# Altium schematic sheet interfaces.SchDoc(interfaces)
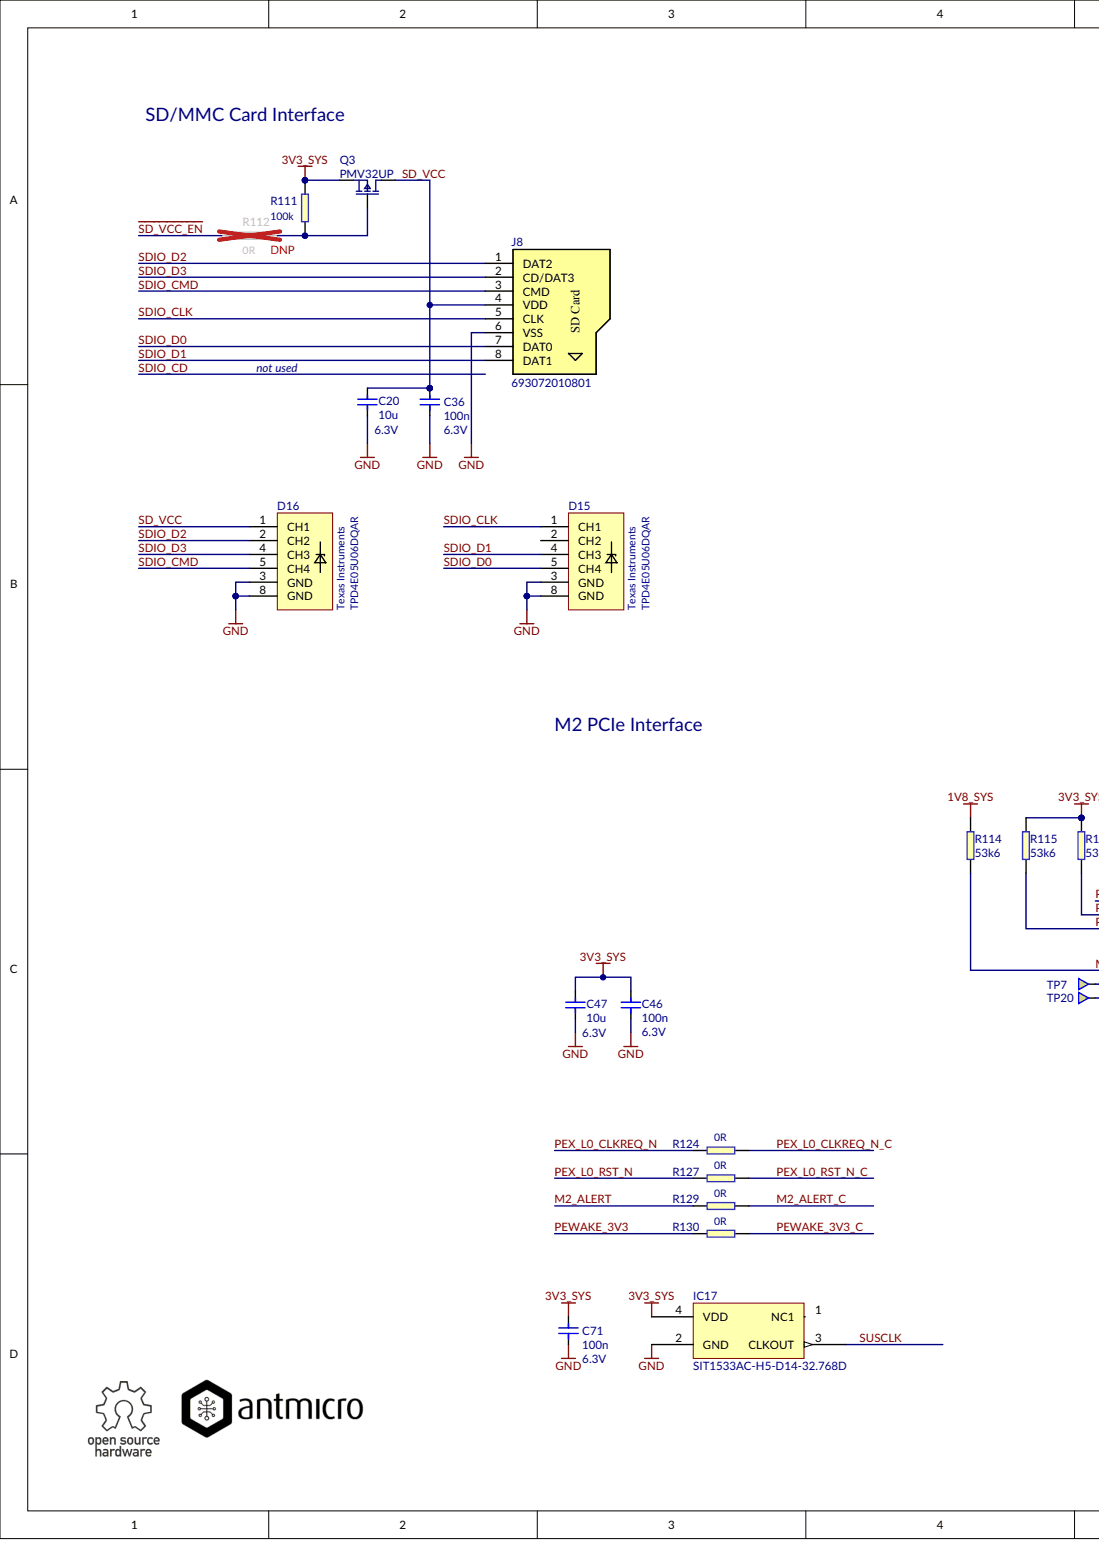
[diagram p0: interfaces.SchDoc, left]
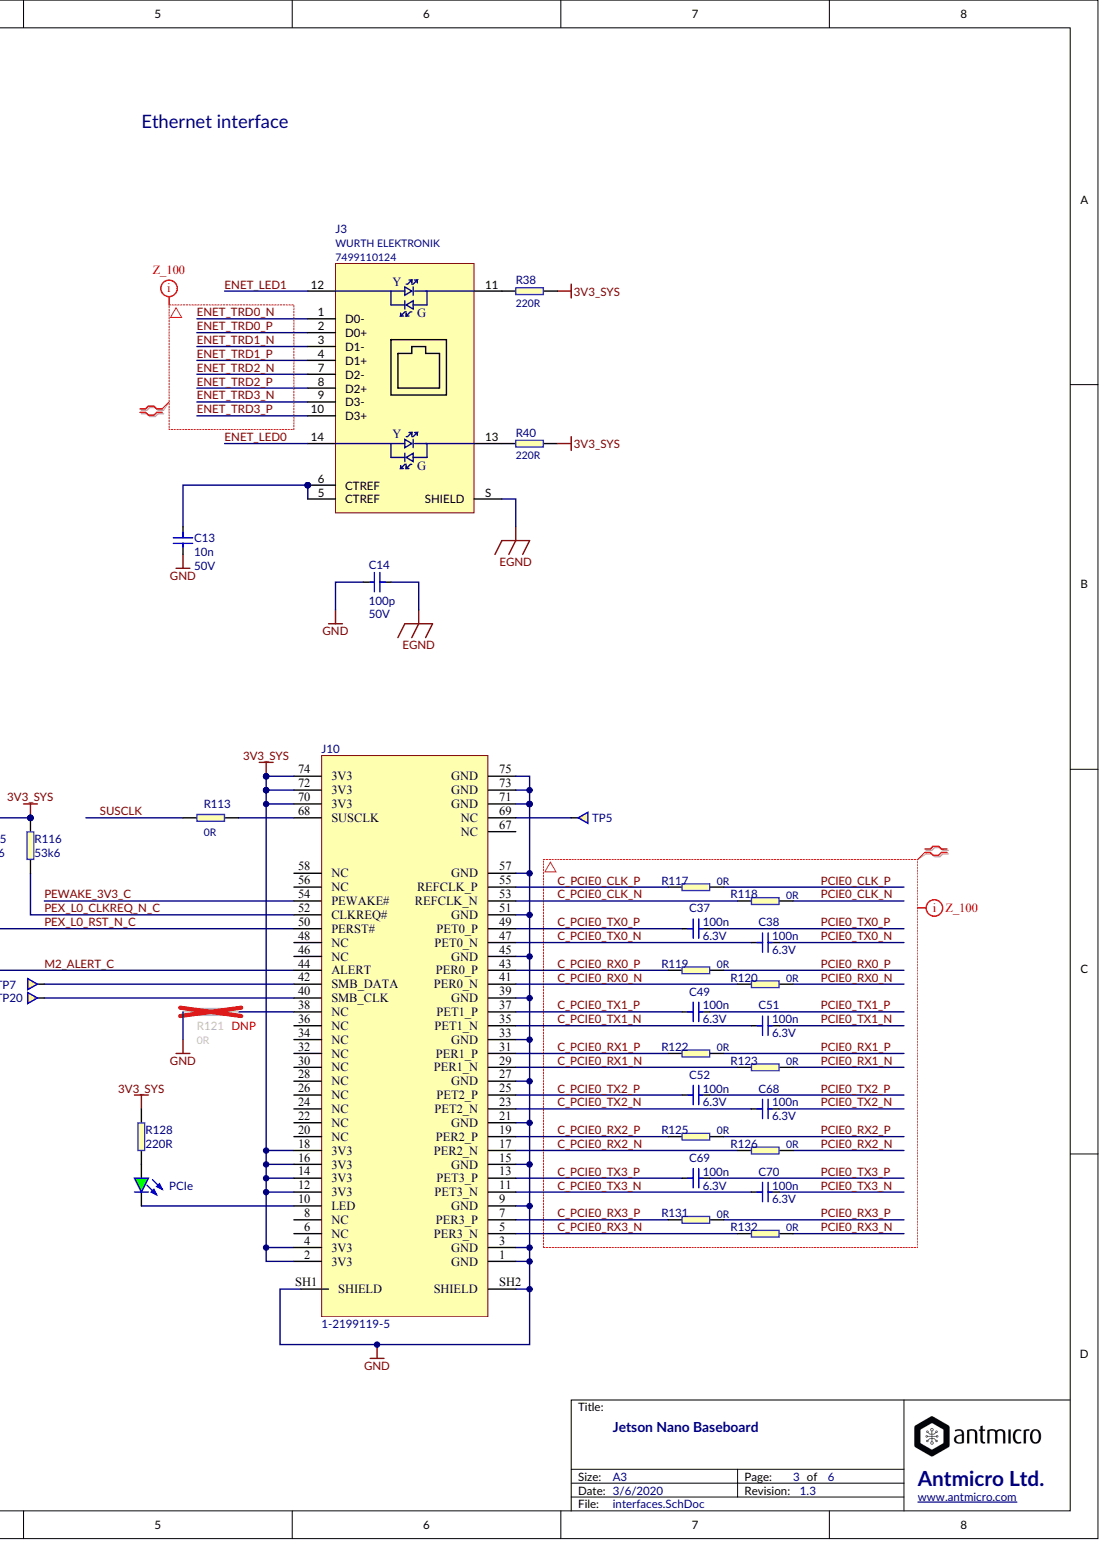
[diagram p1: interfaces.SchDoc, right]

## Parts
C13  Voltage: 50V  (hidden comment: 10n)
C14  Voltage: 50V  (hidden comment: 100p)
C20  Voltage: 6.3V  (hidden comment: 10u)
C36  Voltage: 6.3V  (hidden comment: 100n)
C37  Voltage: 6.3V  (hidden comment: 100n)
C38  Voltage: 6.3V  (hidden comment: 100n)
C46  Voltage: 6.3V  (hidden comment: 100n)
C47  Voltage: 6.3V  (hidden comment: 10u)
C49  Voltage: 6.3V  (hidden comment: 100n)
C51  Voltage: 6.3V  (hidden comment: 100n)
C52  Voltage: 6.3V  (hidden comment: 100n)
C68  Voltage: 6.3V  (hidden comment: 100n)
C69  Voltage: 6.3V  (hidden comment: 100n)
C70  Voltage: 6.3V  (hidden comment: 100n)
C71  Voltage: 6.3V  (hidden comment: 100n)
D15  Manufacturer: Texas Instruments  PartSymbol: TPD4E05U06DQAR  (hidden comment: TPD4E05U06)
D16  Manufacturer: Texas Instruments  PartSymbol: TPD4E05U06DQAR  (hidden comment: TPD4E05U06)
IC17  SIT1533AC-H5-D14-32.768D
J3  Manufacturer: WURTH ELEKTRONIK  PartSymbol: 7499110124  (hidden comment: WE_7499110124)
J8  693072010801
J10  1-2199119-5
PCIe  KPT-1608SGC  (value not drawn)
Q3  PMV32UP
R38  220R
R40  220R
R111  100k
R112  0R
R113  0R
R114  53k6
R115  53k6
R116  53k6
R117  0R
R118  0R
R119  0R
R120  0R
R121  0R
R122  0R
R123  0R
R124  0R
R125  0R
R126  0R
R127  0R
R128  220R
R129  0R
R130  0R
R131  0R
R132  0R
TP5  Testpad  (value not drawn)
TP7  Testpad  (value not drawn)
TP20  Testpad  (value not drawn)

## Nets
1V8_SYS: R114.2
3V3_SYS: C46.2 C47.2 C71.2 IC17.4(VDD) J10.2(3V3) J10.4(3V3) J10.12(3V3) J10.14(3V3) J10.16(3V3) J10.18(3V3) J10.70(3V3) J10.72(3V3) J10.74(3V3) Q3.2 R38.2 R40.1 R111.1 R115.2 R116.2 R128.2
EGND: C14.1 J3.S(SHIELD)
GND: C13.2 C14.2 C20.1 C36.1 C46.1 C47.1 C71.1 D15.3(GND) D15.8(GND) D16.3(GND) D16.8(GND) IC17.2(GND) J8.6(VSS) J10.1(GND) J10.3(GND) J10.9(GND) J10.15(GND) J10.21(GND) J10.27(GND) J10.33(GND) J10.39(GND) J10.45(GND) J10.51(GND) J10.57(GND) J10.71(GND) J10.73(GND) J10.75(GND) J10.SH1(SHIELD) J10.SH2(SHIELD) R121.1
~{PEWAKE_3V3}: R130.1
~{PEWAKE_3V3_C}: J10.54(PEWAKE#) R130.2
C_PCIE0_CLK_N: J10.53(REFCLK_N) R118.1
C_PCIE0_CLK_P: J10.55(REFCLK_P) R117.1
C_PCIE0_RX0_N: J10.41(PER0_N) R120.1
C_PCIE0_RX0_P: J10.43(PER0_P) R119.1
C_PCIE0_RX1_N: J10.29(PER1_N) R123.1
C_PCIE0_RX1_P: J10.31(PER1_P) R122.1
C_PCIE0_RX2_N: J10.17(PER2_N) R126.1
C_PCIE0_RX2_P: J10.19(PER2_P) R125.1
C_PCIE0_RX3_N: J10.5(PER3_N) R132.1
C_PCIE0_RX3_P: J10.7(PER3_P) R131.1
C_PCIE0_TX0_N: C38.1 J10.47(PET0_N)
C_PCIE0_TX0_P: C37.1 J10.49(PET0_P)
C_PCIE0_TX1_N: C51.1 J10.35(PET1_N)
C_PCIE0_TX1_P: C49.1 J10.37(PET1_P)
C_PCIE0_TX2_N: C68.1 J10.23(PET2_N)
C_PCIE0_TX2_P: C52.1 J10.25(PET2_P)
C_PCIE0_TX3_N: C70.1 J10.11(PET3_N)
C_PCIE0_TX3_P: C69.1 J10.13(PET3_P)
ENET_LED0: J3.14
ENET_LED1: J3.12
ENET_TRD0_N: J3.1(D0-)
ENET_TRD0_P: J3.2(D0+)
ENET_TRD1_N: J3.3(D1-)
ENET_TRD1_P: J3.4(D1+)
ENET_TRD2_N: J3.7(D2-)
ENET_TRD2_P: J3.8(D2+)
ENET_TRD3_N: J3.9(D3-)
ENET_TRD3_P: J3.10(D3+)
M2_ALERT: R129.1
M2_ALERT_C: J10.44(ALERT) R114.1 R129.2
PCIE0_CLK_N: R118.2
PCIE0_CLK_P: R117.2
PCIE0_RX0_N: R120.2
PCIE0_RX0_P: R119.2
PCIE0_RX1_N: R123.2
PCIE0_RX1_P: R122.2
PCIE0_RX2_N: R126.2
PCIE0_RX2_P: R125.2
PCIE0_RX3_N: R132.2
PCIE0_RX3_P: R131.2
PCIE0_TX0_N: C38.2
PCIE0_TX0_P: C37.2
PCIE0_TX1_N: C51.2
PCIE0_TX1_P: C49.2
PCIE0_TX2_N: C68.2
PCIE0_TX2_P: C52.2
PCIE0_TX3_N: C70.2
PCIE0_TX3_P: C69.2
PEX_L0_CLKREQ_N: R124.1
PEX_L0_CLKREQ_N_C: J10.52(CLKREQ#) R116.1 R124.2
PEX_L0_RST_N: R127.1
PEX_L0_RST_N_C: J10.50(PERST#) R115.1 R127.2
~{SD_VCC_EN}: R112.1
SD_VCC: C20.2 C36.2 D16.1(CH1) J8.4(VDD) Q3.3
SDIO_CLK: D15.1(CH1) J8.5(CLK)
SDIO_CMD: D16.5(CH4) J8.3(CMD)
SDIO_D0: D15.5(CH4) J8.7(DAT0)
SDIO_D1: D15.4(CH3) J8.8(DAT1)
SDIO_D2: D16.2(CH2) J8.1(DAT2)
SDIO_D3: D16.4(CH3) J8.2(CD/DAT3)
SUSCLK: IC17.3(CLKOUT) R113.1
NetC13_1: C13.1 J3.5(CTREF) J3.6(CTREF)
NetJ3_11: J3.11 R38.1
NetJ3_13: J3.13 R40.2
NetJ10_10: J10.10(LED) PCIe.K
NetJ10_38: J10.38(NC) R121.2
NetJ10_40: J10.40(SMB_CLK) TP20.1
NetJ10_42: J10.42(SMB_DATA) TP7.1
NetJ10_68: J10.68(SUSCLK) R113.2
NetJ10_69: J10.69(NC) TP5.1
NetPCIe_A: PCIe.A R128.1
NetQ3_1: Q3.1 R111.2 R112.2
N00281:
N00282:
N00283:
N00284:
SDIO_CD:

Not connected: D15 2(CH2); IC17 1; J10 6(NC) 8(NC) 20(NC) 22(NC) 24(NC) 26(NC) 28(NC) 30(NC) 32(NC) 34(NC) 36(NC) 46(NC) 48(NC) 56(NC) 58(NC) 67(NC)

## Library data of the parts
C13 = (no symbol name): PartNumber: C_10n_0402; Manufacturer: AVX; PartSymbol: 04025G103ZAT2A; Value: 10n
C14 = (no symbol name): PartNumber: C_100p_0402; Manufacturer: WALSIN; PartSymbol: 0402N101J500CT; Class: Capacitor; Value: 100p; Supplier1: Farnell; SupplierPartNumber1: 2496792
C20 C47 = (no symbol name): Manufacturer: Walsin; PartNumber: C_10u_0603; PartSymbol: 0603X106M6R3CT; Value: 10u
C36-C38 C46 C49 C51 C52 C68-C71 = (no symbol name): Manufacturer: TDK; PartNumber: C_100n_0402; PartSymbol: C1005X5R0J104K050BA; Value: 100n
D15 D16 = (no symbol name): PartNumber: TPD4E05U06_PASS; Value: TPD4E05U06
IC17 = (no symbol name): Manufacturer: SiTIME; PartNumber: SIT1533AC-H5-D14-32.768D; Value: SIT1533AC-H5-D14-32.768D; PartSymbol: SIT1533AC-H5-D14-32.768D
J8 = (no symbol name): PartNumber: WE_693072010801; Manufacturer: WURTH ELEKTRONIK; Pinsnumber: 8; Value: 693072010801; MPN: 693072010801
J10 = (no symbol name): Manufacturer: TE; PartNumber: 1-2199119-5; Value: 1-2199119-5
PCIe = (no symbol name): PartNumber: LED_G; Manufacturer: KINGBRIGHT; Value: KPT-1608SGC; PartSymbol: KPT-1608SGC
Q3 = (no symbol name): Manufacturer: NXP; PartNumber: PMV32UP; Value: PMV32UP; PartSymbol: PMV32UP
R38 R40 = (no symbol name): PartNumber: R_220R_0402; Manufacturer: TE Connectivity; PartSymbol: CRG0402J220R; Value: 220R
R111 = (no symbol name): Manufacturer: BOURNS; PartNumber: R_100k_0603; PartSymbol: CR0603-FX-1003HLF; Value: 100k
R112 R113 R117-R127 R129-R132 = (no symbol name): PartNumber: R_0R_0402; Manufacturer: Panasonic; PartSymbol: ERJ2GE0R00X; Value: 0R
R114-R116 = (no symbol name): PartNumber: R_53k6_0402; Manufacturer: TE CONECTIVITY; PartSymbol: CPF0402B53K6E1; Value: 53k6
R128 = (no symbol name): PartNumber: R_220R_0402; Manufacturer: TE CONECTIVITY; PartSymbol: CRG0402J220R; Value: 220R
TP5 TP7 TP20 = (no symbol name): PartNumber: TP-R-1.5
J3 = (no symbol name): PartNumber: WE_7499110124; Value: WE_7499110124
